# Altium schematic sheet UpConverter.SchDoc
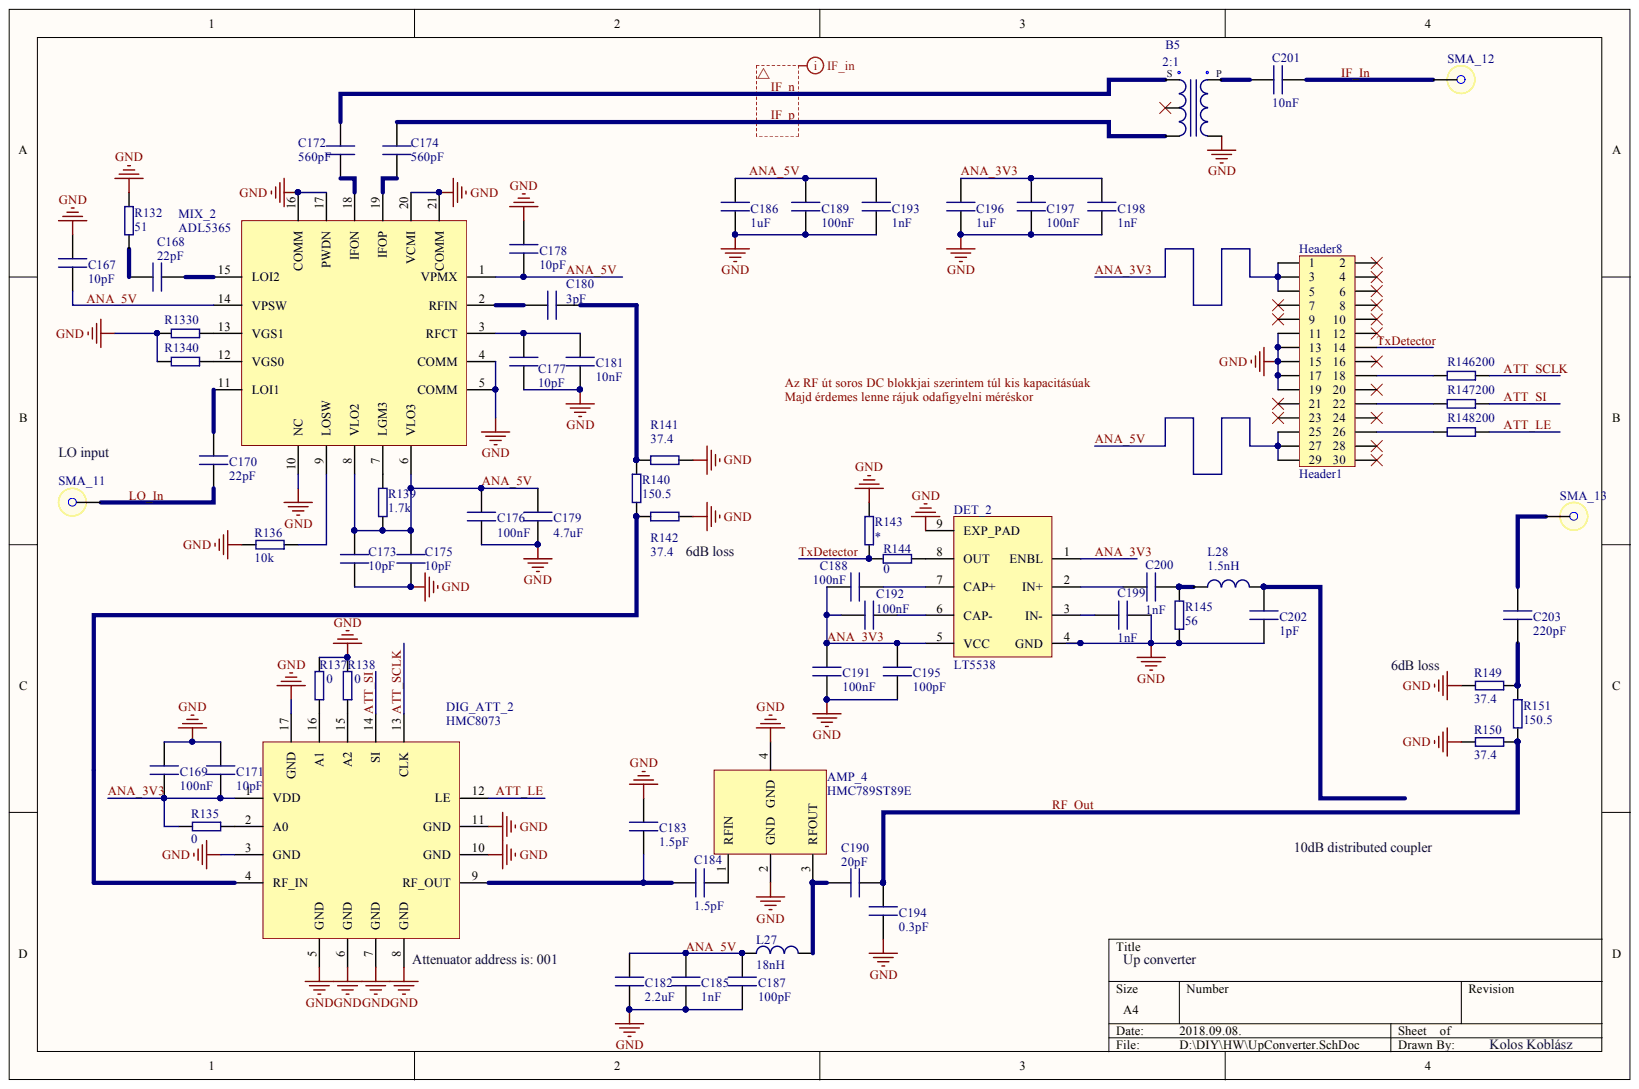
[diagram p0: UpConverter.SchDoc]

## Parts
AMP_4  HMC789ST89E
B5  ImpedanceTransformation P: 2:1  [SMD]  (hidden comment: Balun)
C167  value 10pF  (hidden comment: Cap1)
C168  value 22pF  (hidden comment: Cap1)
C169  value 100nF  (hidden comment: Cap1)
C170  value 22pF  (hidden comment: Cap1)
C171  value 10pF  (hidden comment: Cap1)
C172  value 560pF  (hidden comment: Cap1)
C173  value 10pF  (hidden comment: Cap1)
C174  value 560pF  (hidden comment: Cap1)
C175  value 10pF  (hidden comment: Cap1)
C176  value 100nF  (hidden comment: Cap1)
C177  value 10pF  (hidden comment: Cap1)
C178  value 10pF  (hidden comment: Cap1)
C179  value 4.7uF  (hidden comment: Cap1)
C180  value 3pF  (hidden comment: Cap1)
C181  value 10nF  (hidden comment: Cap1)
C182  value 2.2uF  (hidden comment: Cap1)
C183  value 1.5pF  (hidden comment: Cap1)
C184  value 1.5pF  (hidden comment: Cap1)
C185  value 1nF  (hidden comment: Cap1)
C186  value 1uF  (hidden comment: Cap1)
C187  value 100pF  (hidden comment: Cap1)
C188  value 100nF  (hidden comment: Cap1)
C189  value 100nF  (hidden comment: Cap1)
C190  value 20pF  (hidden comment: Cap1)
C191  value 100nF  (hidden comment: Cap1)
C192  value 100nF  (hidden comment: Cap1)
C193  value 1nF  (hidden comment: Cap1)
C194  value 0.3pF  (hidden comment: Cap1)
C195  value 100pF  (hidden comment: Cap1)
C196  value 1uF  (hidden comment: Cap1)
C197  value 100nF  (hidden comment: Cap1)
C198  value 1nF  (hidden comment: Cap1)
C199  value 1nF  (hidden comment: Cap1)
C200  value 1nF  (hidden comment: Cap1)
C201  value 10nF  (hidden comment: Cap1)
C202  value 1pF  (hidden comment: Cap1)
C203  value 220pF  (hidden comment: Cap1)
DET_2  LT5538
DIG_ATT_2  HMC8073
Header8  Header1  [Header1]
L27  value 18nH  [SMD]  (hidden comment: Inductor)
L28  value 1.5nH  [SMD]  (hidden comment: Inductor)
MIX_2  ADL5365
R132  value 51  [SMD]  (hidden comment: Resistor)
R133  value 0  [SMD]  (hidden comment: Resistor)
R134  value 0  [SMD]  (hidden comment: Resistor)
R135  value 0  [SMD]  (hidden comment: Resistor)
R136  value 10k  [SMD]  (hidden comment: Resistor)
R137  value 0  [SMD]  (hidden comment: Resistor)
R138  value 0  [SMD]  (hidden comment: Resistor)
R139  value 1.7k  [SMD]  (hidden comment: Resistor)
R140  value 150.5  [SMD]  (hidden comment: Resistor)
R141  value 37.4  [SMD]  (hidden comment: Resistor)
R142  value 37.4  [SMD]  (hidden comment: Resistor)
R143  Resistor  [SMD]  (value not drawn)
R144  value 0  [SMD]  (hidden comment: Resistor)
R145  value 56  [SMD]  (hidden comment: Resistor)
R146  value 200  [SMD]  (hidden comment: Resistor)
R147  value 200  [SMD]  (hidden comment: Resistor)
R148  value 200  [SMD]  (hidden comment: Resistor)
R149  value 37.4  [SMD]  (hidden comment: Resistor)
R150  value 37.4  [SMD]  (hidden comment: Resistor)
R151  value 150.5  [SMD]  (hidden comment: Resistor)
SMA_11  SMA1
SMA_12  SMA1
SMA_13  SMA1

## Nets
GND: AMP_4.2(GND) AMP_4.4(GND) B5.4 C167.1 C169.2 C171.2 C173.1 C175.2 C176.1 C177.1 C178.1 C179.1 C181.1 C182.2 C183.1 C185.2 C186.1 C187.2 C189.1 C191.2 C193.1 C194.2 C195.2 C196.1 C197.1 C198.1 C199.1 C202.1 DET_2.4(GND) DET_2.9(EXP_PAD) DIG_ATT_2.3(GND) DIG_ATT_2.5(GND) DIG_ATT_2.6(GND) DIG_ATT_2.7(GND) DIG_ATT_2.8(GND) DIG_ATT_2.10(GND) DIG_ATT_2.11(GND) DIG_ATT_2.17(GND) Header8.11 Header8.13 Header8.15 Header8.17 Header8.19 MIX_2.4(COMM) MIX_2.5(COMM) MIX_2.10(NC) MIX_2.16(COMM) MIX_2.17(PWDN) MIX_2.20(VCMI) MIX_2.21(COMM) R132.1 R133.2 R134.2 R136.2 R137.2 R138.2 R141.2 R142.2 R143.2 R145.1 R149.2 R150.2
ANA_3V3: C169.1 C171.1 C188.2 C191.1 C192.2 C195.1 C196.2 C197.2 C198.2 DET_2.1(ENBL) DET_2.5(VCC) DIG_ATT_2.1(VDD) Header8.1 Header8.3 Header8.5 R135.2
ANA_5V: C167.2 C173.2 C175.1 C176.2 C178.2 C179.2 C182.1 C185.1 C186.2 C187.1 C189.2 C193.2 Header8.25 Header8.27 Header8.29 L27.2 MIX_2.1(VPMX) MIX_2.6(VLO3) MIX_2.8(VLO2) MIX_2.14(VPSW) R139.1
ATT_LE: DIG_ATT_2.12(LE) R148.1
ATT_SCLK: DIG_ATT_2.13(CLK) R146.1
ATT_SI: DIG_ATT_2.14(SI) R147.1
IF_IN: C201.1 SMA_12.1
IF_N: B5.1 C172.1
IF_P: B5.3 C174.1
LO_IN: C170.1 SMA_11.1
RF_OUT: C190.2 C194.1 R150.1 R151.2
TXDETECTOR: Header8.14 R143.1 R144.1
NetAMP_4_1: AMP_4.1(RFIN) C184.2
NetAMP_4_3: AMP_4.3(RFOUT) C190.1 L27.1
NetB5_5: B5.5 C201.2
NetC168_1: C168.1 R132.2
NetC168_2: C168.2 MIX_2.15(LOI2)
NetC170_2: C170.2 MIX_2.11(LOI1)
NetC172_2: C172.2 MIX_2.18(IFON)
NetC174_2: C174.2 MIX_2.19(IFOP)
NetC177_2: C177.2 C181.2 MIX_2.3(RFCT)
NetC180_1: C180.1 R140.1 R141.1
NetC180_2: C180.2 MIX_2.2(RFIN)
NetC183_2: C183.2 C184.1 DIG_ATT_2.9(RF_OUT)
NetC188_1: C188.1 DET_2.7(CAP+)
NetC192_1: C192.1 DET_2.6(CAP-)
NetC199_2: C199.2 DET_2.3(IN-)
NetC200_1: C200.1 L28.2 R145.2
NetC200_2: C200.2 DET_2.2(IN+)
NetC202_2: C202.2 L28.1
NetC203_1: C203.1 R149.1 R151.1
NetC203_2: C203.2 SMA_13.1
NetDET_2_8: DET_2.8(OUT) R144.2
NetDIG_ATT_2_2: DIG_ATT_2.2(A0) R135.1
NetDIG_ATT_2_4: DIG_ATT_2.4(RF_IN) R140.2 R142.1
NetDIG_ATT_2_15: DIG_ATT_2.15(A2) R138.1
NetDIG_ATT_2_16: DIG_ATT_2.16(A1) R137.1
NetHeader8_18: Header8.18 R146.2
NetHeader8_22: Header8.22 R147.2
NetHeader8_26: Header8.26 R148.2
NetMIX_2_7: MIX_2.7(LGM3) R139.2
NetMIX_2_9: MIX_2.9(LOSW) R136.1
NetMIX_2_12: MIX_2.12(VGS0) R134.1
NetMIX_2_13: MIX_2.13(VGS1) R133.1
AZ RF úT SOROS DC BLOKKJAI SZERINTEM TúL KIS KAPACITáSúAK:
MAJD éRDEMES LENNE RáJUK ODAFIGYELNI MéRéSKOR:
N00426:

Not connected: B5 2; Header8 2 4 6 7 8 9 10 12 16 20 21 23 24 28 30

## Library data of the parts
AMP_4 = HMC789ST89E: Gain Block MMIC; Manufacturer: Analog Devices; Component Kind: Standard; Library Name: RadioProjectSchLib.SchLib; Order Code: HMC789ST89E; Package Description: SOT89; PartNumber: HMC789ST89E
B5 = Balun1: SMD Balun; MaxFrequency: 500MHz; MinFrequency: 5MHz; Component Kind: Standard; Library Name: RadioProjectSchLib.SchLib
C167-C203 = Cap1: SMD Capacitor; MaxVolt: 16V; Precision: 1%; Component Kind: Standard; Library Name: RadioProjectSchLib.SchLib
DET_2 = LT5538: Detector; Manufacturer: Analog Devices; Order Code: LT5538IDD#PBF; Component Kind: Standard; Library Name: RadioProjectSchLib.SchLib; Package Description: 8-Lead Plastic DFN; PartNumber: LT5538
DIG_ATT_2 = HMC8073: Digital Attenuator; Manufacturer: Analog Devices; Order Code: HMC8073LP3DE; Component Kind: Standard; Library Name: RadioProjectSchLib.SchLib; Package Description: 16-Lead LFCSP; PartNumber: HMC8073
Header8 = Header1: 29 Pin Header; Manufacterer: Samtec; PartNumber: TLW-115-01-G-D-002-RA; PinNumber: 29; Pitch: 2.54mm; Row: 2; Component Kind: Standard; Library Name: RadioProjectSchLib.SchLib; Type: 90°
L27 L28 = Ind1: SMD Inductor; MaxCurent: 100mA; Precision: 10%; Component Kind: Standard; Library Name: RadioProjectSchLib.SchLib
MIX_2 = ADL5365: RF Mixer; Manufacturer: Analog Devices; Order Code: ADL5365ACPZ-R7; Component Kind: Standard; Library Name: RadioProjectSchLib.SchLib; Package Description: 20-Lead LFCSP_WQ; PartNumber: ADL5365
R132-R151 = Res1: SMD Resistor; MaxPower: 0.1W; Precision: 1%; Component Kind: Standard; Library Name: RadioProjectSchLib.SchLib
SMA_11-SMA_13 = SMA1: SMA Female; Shape: 90°; Component Kind: Standard; Library Name: RadioProjectSchLib.SchLib; Mounting: TroughHole
